# Altium schematic sheet 音频.SchDoc(音频)
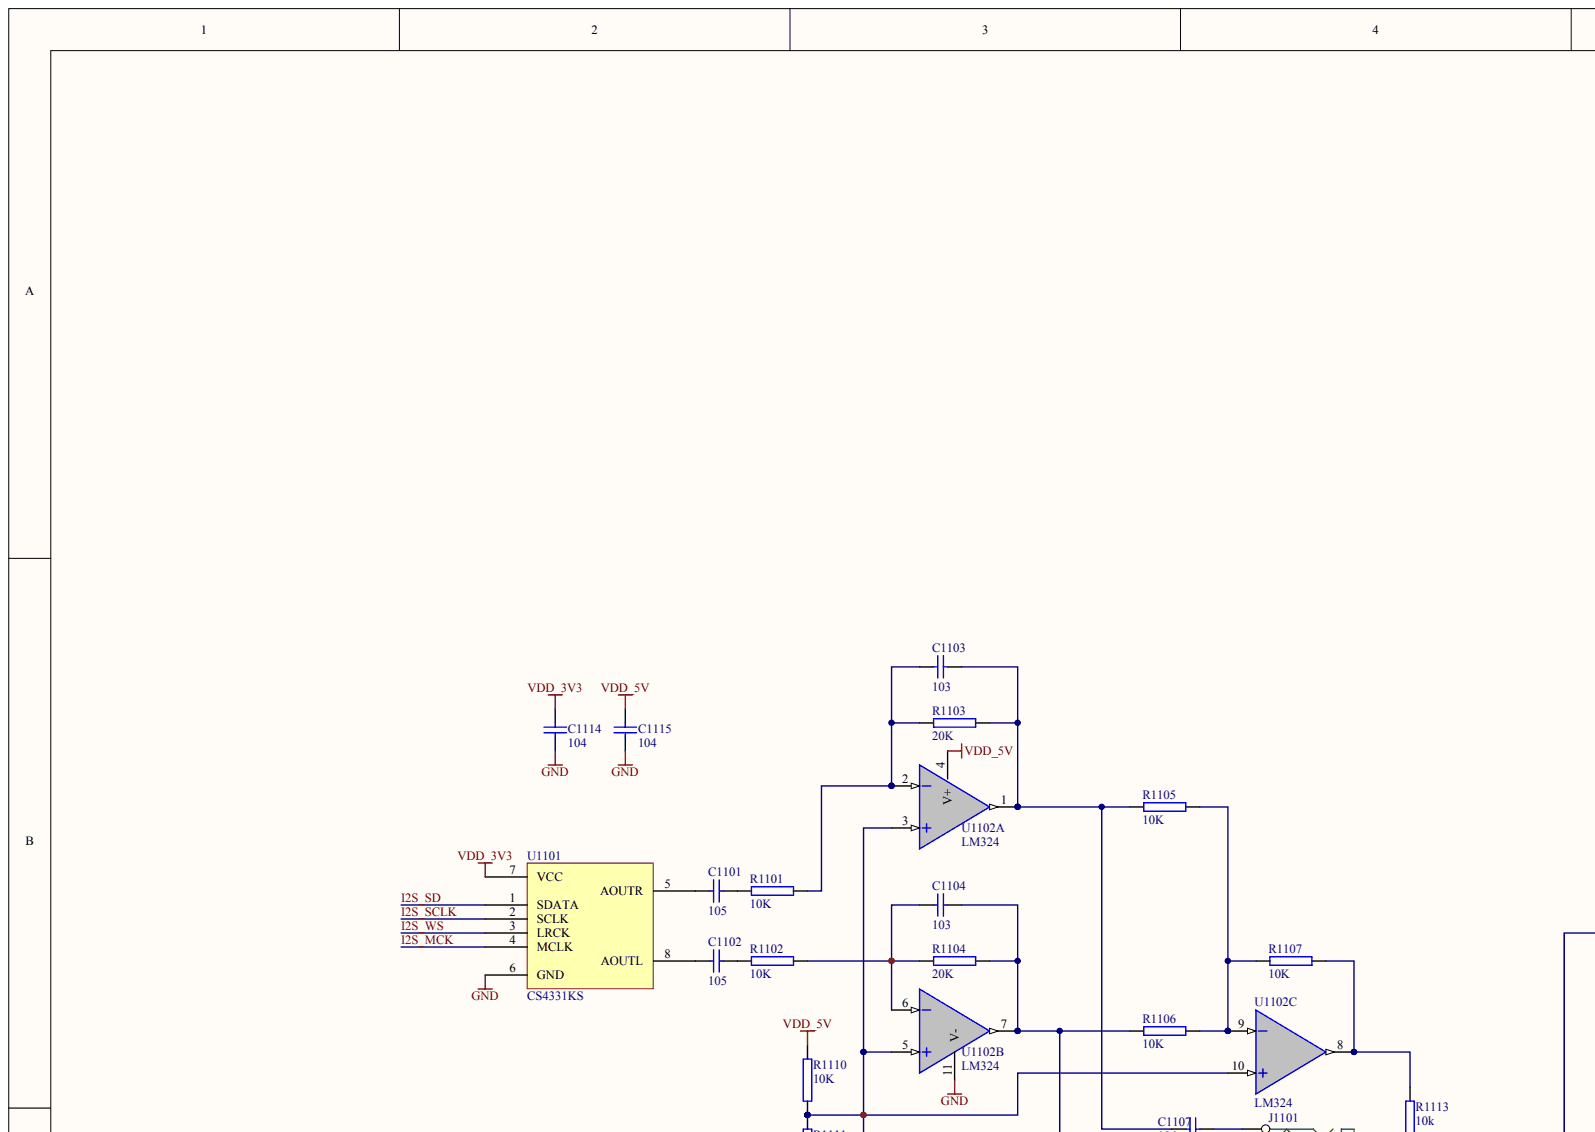
[diagram p0: 音频.SchDoc, top left]
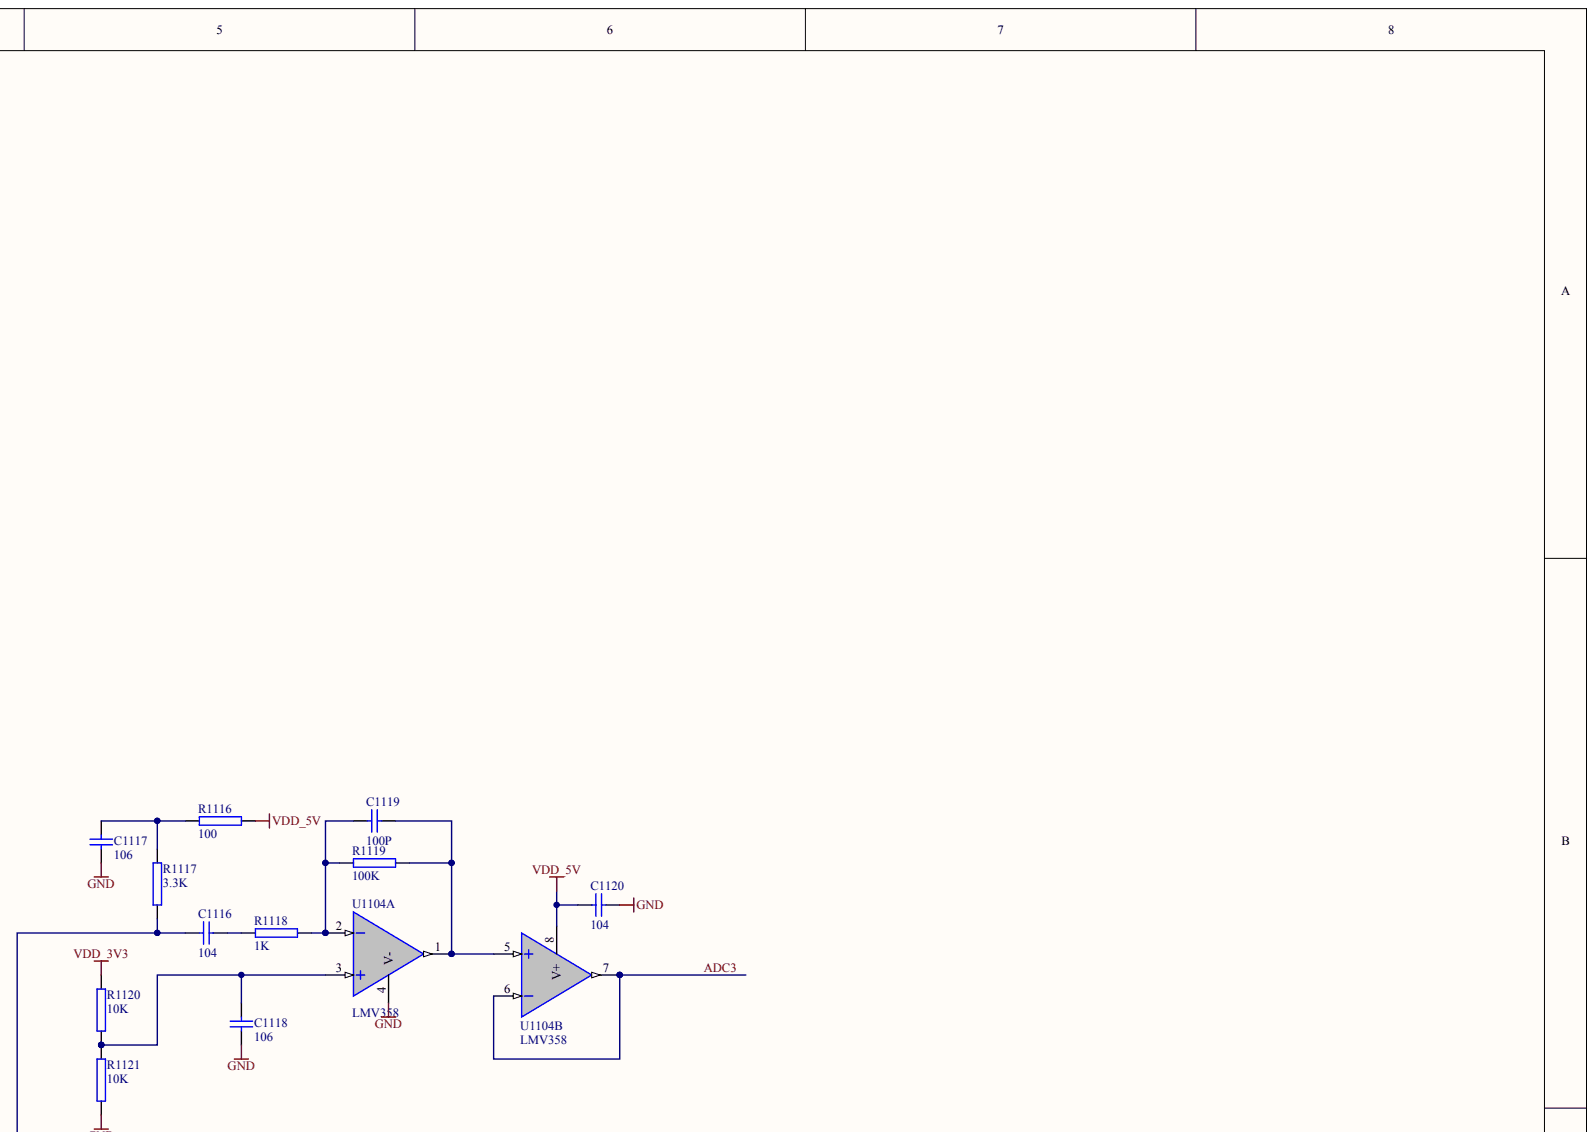
[diagram p1: 音频.SchDoc, top right]
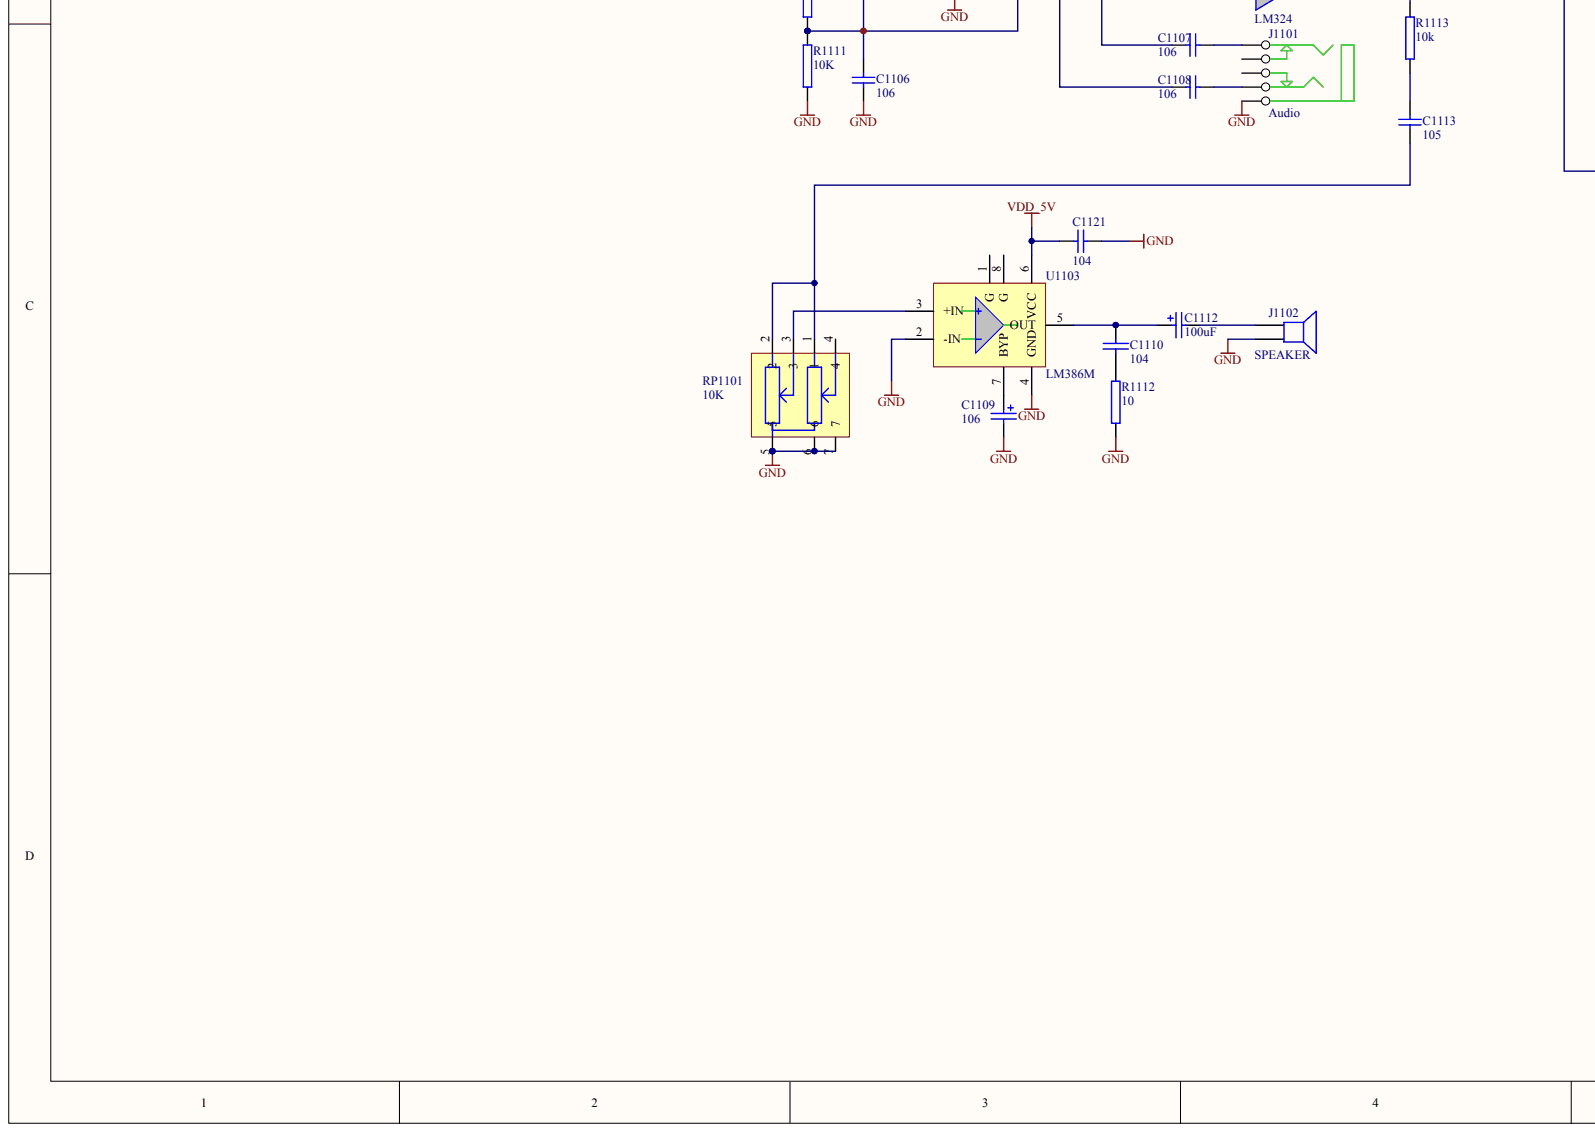
[diagram p2: 音频.SchDoc, bottom left]
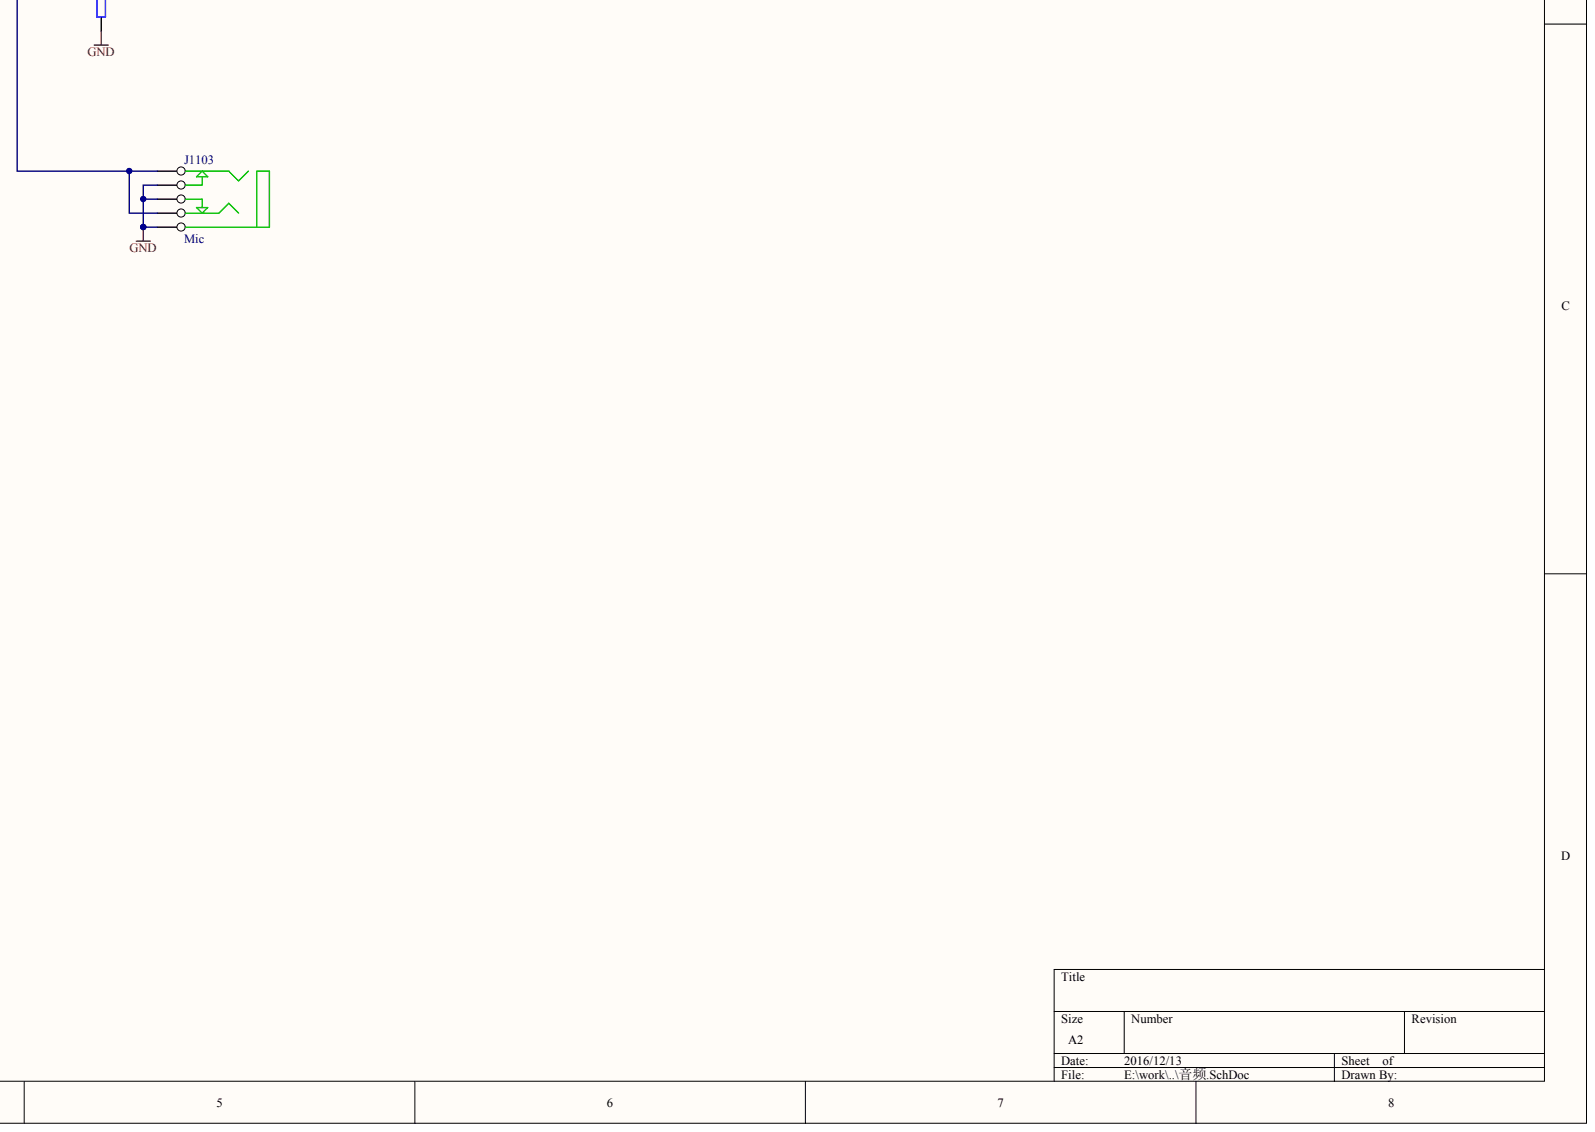
[diagram p3: 音频.SchDoc, bottom right]

## Parts
C1101  105
C1102  105
C1103  103
C1104  103
C1106  106
C1107  106
C1108  106
C1109  106
C1110  104
C1112  100uF
C1113  105
C1114  104
C1115  104
C1116  104
C1117  106
C1118  106
C1119  100P
C1120  104
C1121  104
J1101  Audio
J1102  SPEAKER
J1103  Mic
R1101  10K
R1102  10K
R1103  20K
R1104  20K
R1105  10K
R1106  10K
R1107  10K
R1110  10K
R1111  10K
R1112  10
R1113  10K
R1116  100
R1117  3.3K
R1118  1K
R1119  100K
R1120  10K
R1121  10K
RP1101  10K
U1101  CS4331KS
U1102  LM324  (parts A,B,C)
U1103  LM386M
U1104  LMV358  (parts A,B)

## Nets
GND: C1106.1 C1109.2 C1114.1 C1115.1 C1117.1 C1118.1 C1120.1 C1121.2 J1101.5 J1102.2 J1103.2 J1103.3 J1103.5 R1111.1 R1112.1 R1121.1 RP1101.5 RP1101.6 RP1101.7 U1101.6(GND) U1102.11(V-) U1103.2(-IN) U1103.4(GND) U1104.4(V-)
VDD_3V3: C1114.2 R1120.2 U1101.7(VCC)
VDD_5V: C1115.2 C1120.2 C1121.1 R1110.2 R1116.2 U1102.4(V+) U1103.6(VCC) U1104.8(V+)
ADC3: U1104.6 U1104.7
I2S_MCK: U1101.4(MCLK)
I2S_SCLK: U1101.2(SCLK)
I2S_SD: U1101.1(SDATA)
I2S_WS: U1101.3(LRCK)
NetC1101_1: C1101.1 U1101.5(AOUTR)
NetC1101_2: C1101.2 R1101.1
NetC1102_1: C1102.1 U1101.8(AOUTL)
NetC1102_2: C1102.2 R1102.1
NetC1103_1: C1103.1 R1101.2 R1103.1 U1102.2
NetC1103_2: C1103.2 C1107.1 R1103.2 R1105.1 U1102.1
NetC1104_1: C1104.1 R1102.2 R1104.1 U1102.6
NetC1104_2: C1104.2 C1108.1 R1104.2 R1106.1 U1102.7
NetC1106_2: C1106.2 R1110.1 R1111.2 U1102.3 U1102.5 U1102.10
NetC1107_2: C1107.2 J1101.1
NetC1108_2: C1108.2 J1101.4
NetC1109_1: C1109.1 U1103.7(BYP)
NetC1110_1: C1110.1 R1112.2
NetC1110_2: C1110.2 C1112.1 U1103.5(OUT)
NetC1112_2: C1112.2 J1102.1
NetC1113_1: C1113.1 RP1101.1 RP1101.2
NetC1113_2: C1113.2 R1113.1
NetC1116_1: C1116.1 J1103.1 J1103.4 R1117.1
NetC1116_2: C1116.2 R1118.1
NetC1117_2: C1117.2 R1116.1 R1117.2
NetC1118_2: C1118.2 R1120.1 R1121.2 U1104.3
NetC1119_1: C1119.1 R1118.2 R1119.1 U1104.2
NetC1119_2: C1119.2 R1119.2 U1104.1 U1104.5
NetR1105_2: R1105.2 R1106.2 R1107.1 U1102.9
NetR1107_2: R1107.2 R1113.2 U1102.8
NetRP1101_3: RP1101.3 U1103.3(+IN)

Not connected: J1101 2 3; RP1101 4; U1103 1(G) 8(G)

## Library data of the parts
U1102 U1104 = (no symbol name): Text Field1: Cost : RMB3.00; Text Field2: Tech : USA NS Inc.
C1101-C1104 C1106-C1110 C1112-C1121 J1101-J1103 R1101-R1107 R1110-R1113 R1116-R1121 RP1101 U1101 U1103 = (no symbol name)
  C1101 C1102 C1113: Published: 8-Jun-2000; LatestRevisionDate: 17-Jul-2002; LatestRevisionNote: Re-released for DXP Platform.; Value: 105
  C1103 C1104: Published: 8-Jun-2000; LatestRevisionDate: 17-Jul-2002; LatestRevisionNote: Re-released for DXP Platform.; Value: 103
  C1106-C1108 C1117 C1118: Published: 8-Jun-2000; LatestRevisionDate: 17-Jul-2002; LatestRevisionNote: Re-released for DXP Platform.; Value: 106
  C1114-C1116 C1120 C1121: Published: 8-Jun-2000; LatestRevisionDate: 17-Jul-2002; LatestRevisionNote: Re-released for DXP Platform.; Value: 104
  C1119: Published: 8-Jun-2000; LatestRevisionDate: 17-Jul-2002; LatestRevisionNote: Re-released for DXP Platform.; Value: 100P
  R1101 R1102 R1105-R1107 R1110 R1111 R1120 R1121: Value: 10K
  R1103 R1104: Value: 20K
  R1113: Value: 10k
  R1116: Value: 100
  R1117: Value: 3.3K
  R1118: Value: 1K
  R1119: Value: 100K
  C1101-C1104 C1106-C1108 C1113-C1121: Publisher: Altium Limited
